# Altium schematic sheet Sheet1.SchDoc
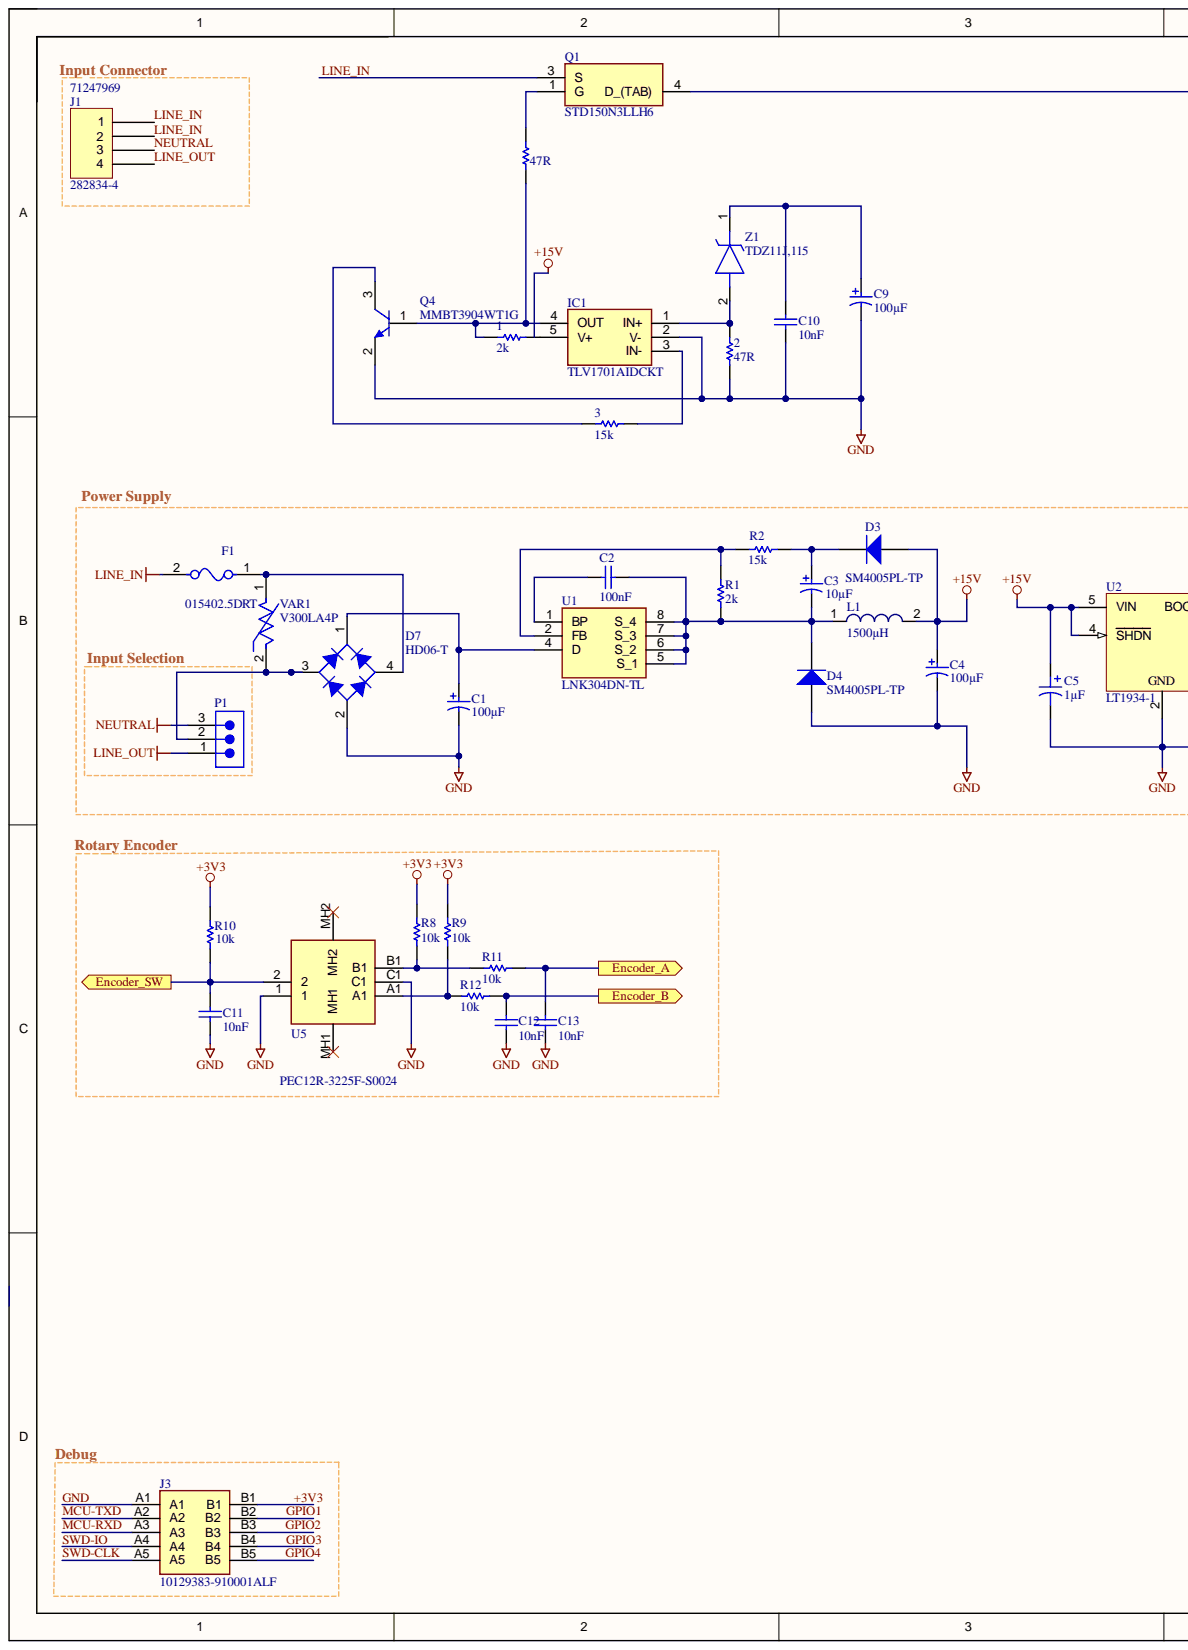
[diagram p0: Sheet1.SchDoc, left]
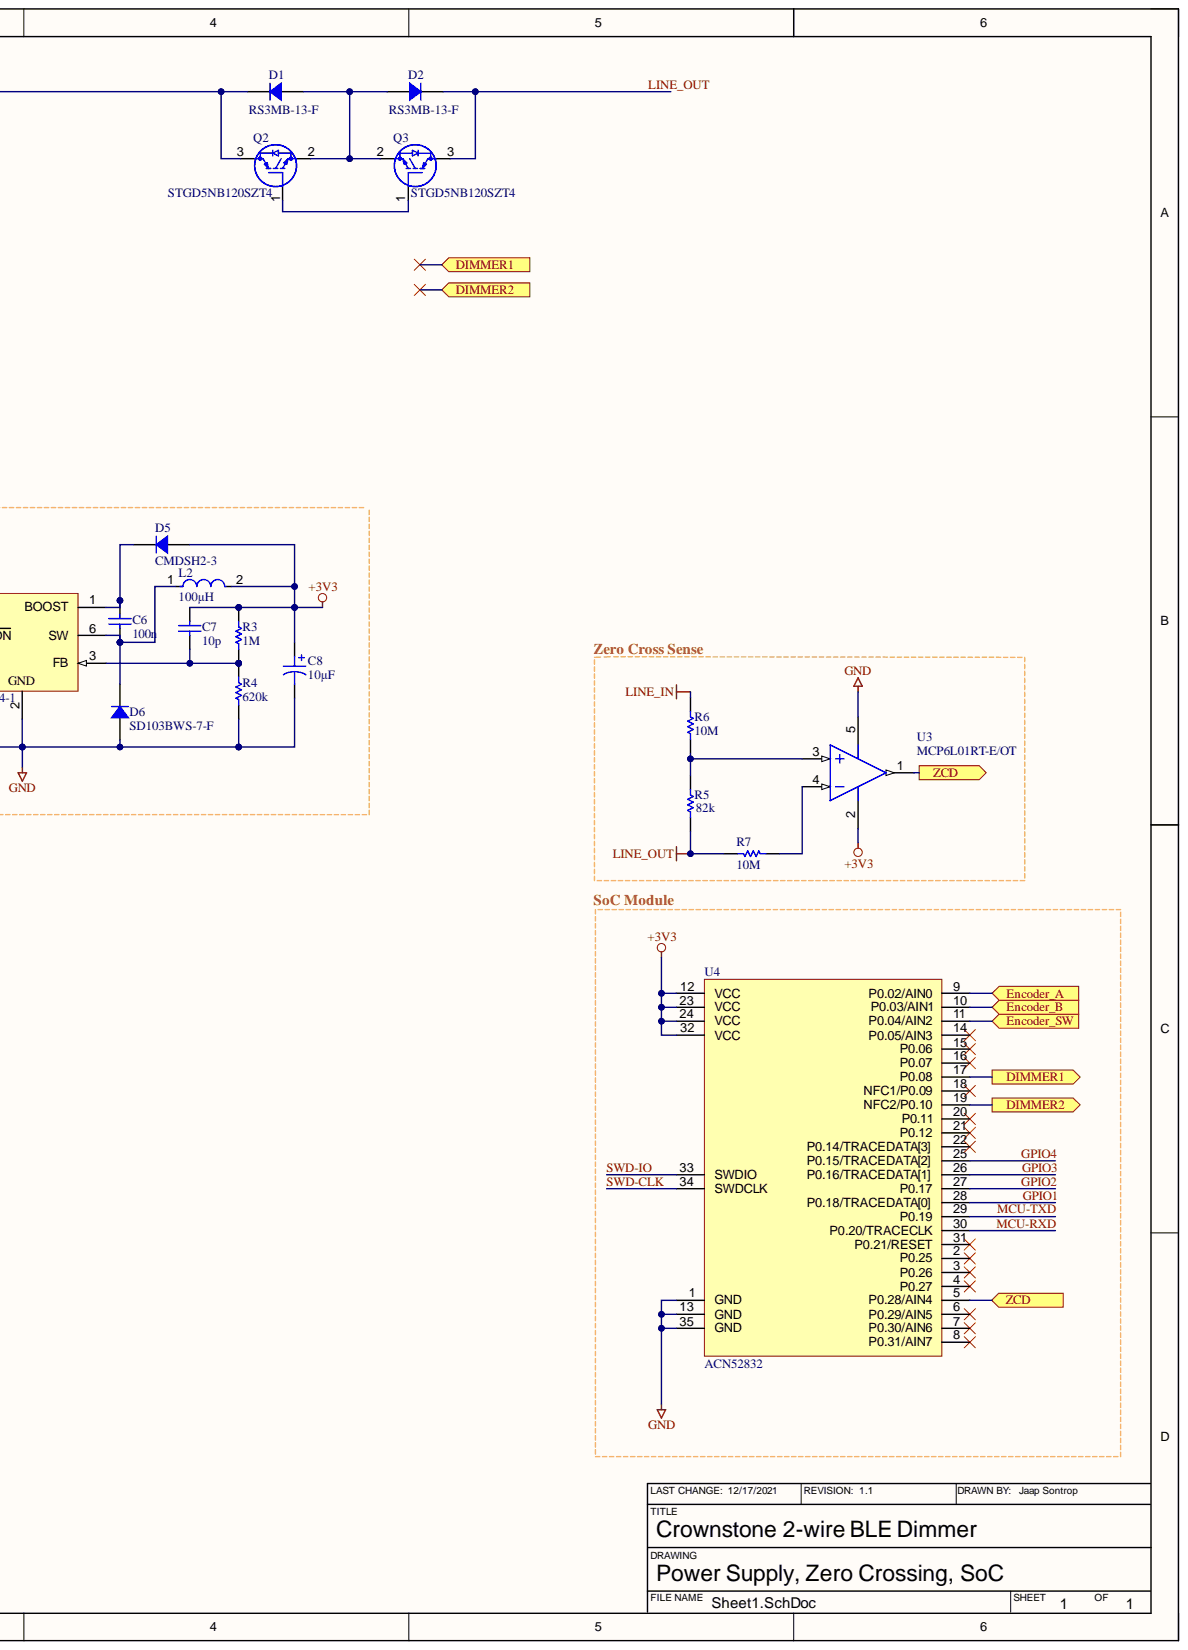
[diagram p1: Sheet1.SchDoc, right]

## Parts
1  2k  [RESC2013X50X30LL20T20]
2  47R  [RESC2013X50X30LL20T20]
3  15k  [RESC2013X50X30LL20T20]
C1  100µF  [NIC-CAPA2-6.3X5.8_V]
C2  100nF  [CAPC2013X145X48NL20T23]
C3  10µF  [NIC-CAPA2-6.3X5.8_V]
C4  100µF  [NIC-CAPA2-6.3X5.8_V]
C5  1µF  [CAP ALSMD POLY 4.3MM H5.5MM]
C6  100n  [CAPC2013X145X48NL20T23]
C7  10p  [CAPC2013X145X48NL20T23]
C8  10µF  [CAP ALSMD 8.3MM H10.5MM]
C9  100µF  [NIC-CAPA2-6.3X5.8_V]
C10  10nF  [CAPC1608X90X35NL15T15]
C11  10nF  [CAPC1608X90X35NL15T15]
C12  10nF  [CAPC1608X90X35NL15T15]
C13  10nF  [CAPC1608X90X35NL15T15]
D1  RS3MB-13-F  [DO214AA SMB]
D2  RS3MB-13-F  [DO214AA SMB]
D3  Manufacturer Part Number: SM4005PL-TP  [MCC-SOD-123FL_V]  (hidden comment: Dfeedback)
D4  Manufacturer Part Number: SM4005PL-TP  [MCC-SOD-123FL_V]  (hidden comment: Dfreewheel)
D5  CMDSH2-3  [SOD323]
D6  SD103BWS-7-F  [SOD323]
D7  HD06-T  [DIOD-MiniDIP-4_V]
F1  015402.5DRT  [FP-0154-MFG]
IC1  TLV1701AIDCKT  [SOT65P210X110-5N]
J1  282834-4  Supplier Part Number 2: 71247969  [TE 282834-4]
J3  10129383-910001ALF  [10129383910001ALF]
L1  1500µH  [1060]
L2  100µH  [FP-LQH3NPH_ME-MFG]
P1  TSW-103-07-F-S  [SMTC-TSW-103-07-X-S]  (value not drawn)
Q1  STD150N3LLH6  [TO228P972X240-3N]
Q2  STGD5NB120SZT4  [LD1117DT33]
Q3  STGD5NB120SZT4  [LD1117DT33]
Q4  MMBT3904WT1G  [ONSC-SC-70-3-419-04_V]
R1  2k  [RESC2013X50X30LL20T20]
R2  15k  [RESC2013X50X30LL20T20]
R3  1M  [RESC2013X50X30LL20T20]
R4  620k  [RESC2013X50X30LL20T20]
R5  82k  [RESC2013X50X30LL20T20]
R6  10M  [RESC2013X50X30LL20T20]
R7  10M  [RESC2013X50X30LL20T20]
R8  10k  [RESC2013X50X30LL20T20]
R9  10k  [RESC2013X50X30LL20T20]
R10  10k  [RESC2013X50X30LL20T20]
R11  10k  [RESC2013X50X30LL20T20]
R12  10k  [RESC2013X50X30LL20T20]
U1  LNK304DN-TL  [SO-8C(D-PACKAGE)]
U2  LT1934-1  [LT-S6-6-TSOT-23_N]
U3  MCP6L01RT-E/OT  [SOT-23-OT5_M]
U4  ACN52832  [ACN52832]  (no BOM)
U5  PEC12R-3225F-S0024  [PEC12R3225FS0024]
VAR1  V300LA4P  [FP-MOV-10D201KTR-MFG]
Z1  TDZ11J,115  [SODFL2512X80N]
  47R  [RESC2013X50X30LL20T20]

## Ports
DIMMER1, DIMMER2, Encoder_A, Encoder_B, Encoder_SW, ZCD

## Nets
+15V: C4.1 C5.1 D3.1 L1.2 U2.4(SHDN) U2.5(VIN)
Net_2: Q1.1 .2
+3V3: C7.2 C8.1 D5.1 J3.B1 L2.2 R3.1 R8.2 R9.2 R10.2 U3.2 U4.12(VCC) U4.23(VCC) U4.24(VCC) U4.32(VCC)
DIMMER1: U4.17(P0.08) ; port DIMMER1
DIMMER2: U4.19(NFC2/P0.10) ; port DIMMER2
Encoder_A: C13.2 R11.1 U4.9(P0.02/AIN0) ; port Encoder_A
Encoder_B: C12.2 R12.1 U4.10(P0.03/AIN1) ; port Encoder_B
Encoder_SW: C11.2 R10.1 U4.11(P0.04/AIN2) U5.2 ; port Encoder_SW
GND: 2.2 C1.2 C4.2 C5.2 C8.2 C9.2 C10.1 C11.1 C12.1 C13.1 D4.1 D6.1 D7.2 IC1.2(V-) J3.A1 Q4.2 R4.2 U2.2 U3.5 U4.1(GND) U4.13(GND) U4.35(GND) U5.1 U5.C1
GPIO1: J3.B2 U4.28(P0.18/TRACEDATA[0])
GPIO2: J3.B3 U4.27(P0.17)
GPIO3: J3.B4 U4.26(P0.16/TRACEDATA[1])
GPIO4: J3.B5 U4.25(P0.15/TRACEDATA[2])
LINE_IN: F1.2 J1.1 J1.2 Q1.3 R6.2
LINE_OUT: D2.2 J1.4 P1.1 Q3.3 R5.2 R7.2
MCU-RXD: J3.A3 U4.30(P0.20/TRACECLK)
MCU-TXD: J3.A2 U4.29(P0.19)
NEUTRAL: J1.3 P1.3
SWD-CLK: J3.A5 U4.34(SWDCLK)
SWD-IO: J3.A4 U4.33(SWDIO)
ZCD: U3.1 U4.5(P0.28/AIN4) ; port ZCD
Net1_1: 1.1 IC1.4(OUT) Q4.1 .1
Net1_2: 1.2 IC1.5(V+)
Net2_1: 2.1 IC1.1(IN+) Z1.2
Net3_1: 3.1 IC1.3(IN-)
Net3_2: 3.2 Q4.3
NetC1_1: C1.1 D7.1 U1.4(D)
NetC2_1: C2.1 C3.2 D4.2 L1.1 R1.1 U1.5(S_1) U1.6(S_2) U1.7(S_3) U1.8(S_4)
NetC2_2: C2.2 U1.1(BP)
NetC3_1: C3.1 D3.2 R2.1
NetC6_1: C6.1 D5.2 U2.1(BOOST)
NetC6_2: C6.2 D6.2 L2.1 U2.6(SW)
NetC7_1: C7.1 R3.2 R4.1 U2.3(FB)
NetC9_1: C9.1 C10.2 Z1.1
NetD1_1: D1.1 D2.1 Q2.2 Q3.2
NetD1_2: D1.2 Q1.4 Q2.3
NetD7_3: D7.3 P1.2 VAR1.2
NetD7_4: D7.4 F1.1 VAR1.1
NetQ2_1: Q2.1 Q3.1
NetR1_2: R1.2 R2.2 U1.2(FB)
NetR5_1: R5.1 R6.1 U3.3
NetR7_1: R7.1 U3.4
NetR8_1: R8.1 R11.2 U5.B1
NetR9_1: R9.1 R12.2 U5.A1

Not connected: U4 2(P0.25) 3(P0.26) 4(P0.27) 6(P0.29/AIN5) 7(P0.30/AIN6) 8(P0.31/AIN7) 14(P0.05/AIN3) 15(P0.06) 16(P0.07) 18(NFC1/P0.09) 20(P0.11) 21(P0.12) 22(P0.14/TRACEDATA[3]) 31(P0.21/RESET); U5 MH1 MH2

## Library data of the parts
1-3 R5-R12  = CMP-2001-04896-1: Manufacturer: Vishay Dale; Part Number: CRCW08050000Z0EA; Component Kind: Standard; Library Name: Altium Content Vault
C1 C3 = CMP-0687-00050-1: [Input cap]; Manufacturer: Nichicon; PartNumber: UUD1C101MCL1GS; Endurance Time: 2000 h; Impedance: 0.44 Ohm; Packing: Dia382mm Reel; Rated Capacitance: 100 uF; Rated Ripple Current (at 100kHz): 230 mA; Rated Voltage: 16 V; Tolerance Rated Capacitance: -20 to 20%; Component Kind: Standard; ComponentLink1Description: Manufacturer URL; ComponentLink1URL: http://www.nichicon.co.jp/; ComponentLink2Description: Datasheet; ComponentLink2URL: http://products.nichicon.co.jp/en/pdf/XJA043/e-ud.pdf; ComponentLink3Description: Package Specification; ComponentLink3URL: http://products.nichicon.co.jp/en/pdf/XJA043/e-ud.pdf; DatasheetVersion: 08/2012; Diameter: 6.3 mm; Length: 5.8 mm; Library Name: Altium Content Vault; Mounting Technology: Surface Mount; PackageDescription: 2-Pin Surface Mount Device, Diameter 6.3 mm, Height 5.8 mm, Pitch 5.4 mm; PackageReference: CAPAE-D6.3H5.8; RoHS: TRUE
C2 C7 = CMP-2007-03167-1: bypass cap; Manufacturer: Vitramon; Part Number: VJ0805Y104KNAAT; Component Kind: Standard; Library Name: Altium Content Vault
C4 C9 = CMP-0687-00050-1: [output cap] (16v) Aluminum Electrolytic Capacitor, UD Series, Low Impedance, 100 uF, 16 V, + / - 20%, -55 to 105 degC, Chip Type, 6.3 x 5.8 mm D x L, Pb-Free, Reel; Manufacturer: Nichicon; PartNumber: UUD1C101MCL1GS; Endurance Time: 2000 h; Impedance: 0.44 Ohm; Packing: Dia382mm Reel; Rated Capacitance: 100 uF; Rated Ripple Current (at 100kHz): 230 mA; Tolerance Rated Capacitance: -20 to 20%; Component Kind: Standard; ComponentLink1Description: Manufacturer URL; ComponentLink1URL: http://www.nichicon.co.jp/; ComponentLink2Description: Datasheet; ComponentLink2URL: http://products.nichicon.co.jp/en/pdf/XJA043/e-ud.pdf; ComponentLink3Description: Package Specification; ComponentLink3URL: http://products.nichicon.co.jp/en/pdf/XJA043/e-ud.pdf; DatasheetVersion: 08/2012; Diameter: 6.3 mm; Length: 5.8 mm; Library Name: Altium Content Vault; Mounting Technology: Surface Mount; PackageDescription: 2-Pin Surface Mount Device, Diameter 6.3 mm, Height 5.8 mm, Pitch 5.4 mm; PackageReference: CAPAE-D6.3H5.8; Rated Voltage: 16 V; RoHS: TRUE
C5 = UWX1H010MCL1GB: CAP ALUM 1UF 20% 50V SMD; Manufacturer: Nichicon; Database Table Name: Capacitors - Aluminum - Electrolytic SMT; Lifetime @ Temp.: 2000 Hrs @ 85°C; Polarization: Polar; Ripple Current @ High Frequency: 12.6mA @ 10kHz; Ripple Current @ Low Frequency: 8.4mA @ 120Hz; Surface Mount Land Size: 0.169" L x 0.169" W (4.30mm x 4.30mm); Applications: General Purpose; Capacitance: 1µF; Component Kind: Standard; ComponentLink1Description: Datasheet; ComponentLink1URL: https://products.nichicon.co.jp/en/pdf/XJA043/e-uwx.pdf; ComponentLink2Description: DigiKey Link; ComponentLink2URL: http://digikey.com/product-detail/en/nichicon/UWX1H010MCL1GB/493-2130-1-ND/590105; Device Package: Radial, Can - SMD; Height - Seated (Max): 0.213" (5.40mm); LastUpdated: 9/2/2020 1:23:44 AM; Library Name: Celestial Altium Library - altium_library.DbLib; Lifecycle Status: Active; Minimum Order: 1; Mounting Type: Surface Mount; Operating Temperature: -40°C ~ 85°C; Package / Case: Radial, Can - SMD; Packaging: TapeAndReel; Part Number: UWX1H010MCL1GB; Part Status: Active; PartId: 7127; Price: 0.05; Series: UWX; Size / Dimension: 0.157" Dia (4.00mm); Supplier 1: DigiKey; Supplier Part Number 1: 493-2130-1-ND; Tolerance: ±20%; Value: 1µF; Voltage - Rated: 50V
C6 = CMP-2007-03167-1: Manufacturer: Vitramon; Part Number: VJ0805Y104KNAAT; Component Kind: Standard; Library Name: Altium Content Vault
C8 = UUX2E6R8MNL1GS: CAP ALUM 6.8UF 20% 250V SMD; Manufacturer: Nichicon; Capacitance: 6.8µF; Database Table Name: Capacitors - Aluminum - Electrolytic SMT; Lifetime @ Temp.: 3000 Hrs @ 105°C; Polarization: Polar; Ripple Current @ High Frequency: 73.48mA @ 10kHz; Ripple Current @ Low Frequency: 44mA @ 120Hz; Surface Mount Land Size: 0.327" L x 0.327" W (8.30mm x 8.30mm); Value: 6.8µF; Applications: General Purpose; Component Kind: Standard; ComponentLink1Description: Datasheet; ComponentLink1URL: http://nichicon-us.com/english/products/pdfs/e-uux.pdf; ComponentLink2Description: DigiKey Link; ComponentLink2URL: http://digikey.com/product-detail/en/nichicon/UUX2E6R8MNL1GS/493-9984-1-ND/3974087; Device Package: Radial, Can - SMD; Height - Seated (Max): 0.413" (10.50mm); LastUpdated: 9/2/2020 1:25:13 AM; Library Name: Celestial Altium Library - altium_library.DbLib; Lifecycle Status: Active; Minimum Order: 1; Mounting Type: Surface Mount; Operating Temperature: -40°C ~ 105°C; Package / Case: Radial, Can - SMD; Packaging: TapeAndReel; Part Number: UUX2E6R8MNL1GS; Part Status: Active; PartId: 7765; Price: 0.25; Series: UUX; Size / Dimension: 0.315" Dia (8.00mm); Supplier 1: DigiKey; Supplier Part Number 1: 493-9984-1-ND; Tolerance: ±20%; Voltage - Rated: 250V
C10-C13 = CMP-2006-04076-1: Manufacturer: AVX Interconnect / Elco; Part Number: 06031C103MAT2A; Component Kind: Standard; Library Name: Altium Content Vault
D1 D2 = RS3MB-13-F: DIODE GEN PURP 1KV 3A SMB; Manufacturer: Diodes Incorporated; Capacitance @ Vr, F: 50pF @ 4V, 1MHz; Current - Reverse Leakage @ Vr: 5µA @ 1000V; Database Table Name: Diodes - Rectifiers - Single; Diode Type: Standard; Reverse Recovery Time (trr): 500ns; Speed: Fast Recovery =< 500ns, > 200mA (Io); Voltage - DC Reverse (Vr) (Max): 1000V; Voltage - Forward (Vf) (Max) @ If: 1.3V @ 3A; Component Kind: Standard; ComponentLink1Description: Datasheet; ComponentLink1URL: //media.digikey.com/pdf/Data%20Sheets/Diodes%20PDFs/RS3A_B~RS3M_B.pdf; ComponentLink2Description: DigiKey Link; ComponentLink2URL: http://digikey.com/product-detail/en/diodes-incorporated/RS3MB-13-F/RS3MB-FDICT-ND/804908; Current - Average Rectified (Io): 3A; LastUpdated: 9/2/2020 1:18:52 AM; Library Name: Celestial Altium Library - altium_library.DbLib; Lifecycle Status: Active; Minimum Order: 1; Mounting Type: Surface Mount; Operating Temperature - Junction: -65°C ~ 150°C; Package / Case: DO-214AA, SMB; Packaging: TapeAndReel; Part Number: RS3MB-13-F; Part Status: Active; PartId: 224; Price: 0.22; Series: -; Supplier 1: DigiKey; Supplier Device Package: SMB; Supplier Part Number 1: RS3MB-FDICT-ND
D3 D4 = CMP-2000-07084-1: Manufacturer: Micro Commercial Components; Average Rectified Current: 1A; Diode Configuration: Single; Diode Type: StandardRecovery; Forward Surge Current Ifsm Max: 30A; Forward Voltage: 1.1V; Max Repetitive Reverse Voltage (Vrrm): 600V; Max Reverse Current: 5uA; Repetitive Reverse Voltage Vrrm Max: 1kV; Category: Rectifier Diodes; Component Kind: Standard; ComponentLink1Description: https://datasheet.ciiva.com/912/sm4001pl-sm4007plsod-123fl-912887.pdf?src-supplier=Digi-Key; ComponentLink1URL: https://datasheet.ciiva.com/912/sm4001pl-sm4007plsod-123fl-912887.pdf?src-supplier=Digi-Key; ComponentLink2Description: https://datasheet.ciiva.com/912/sm4001pl-sm4007plsod-123fl-912885.pdf?src-supplier=Digi-Key; ComponentLink2URL: https://datasheet.ciiva.com/912/sm4001pl-sm4007plsod-123fl-912885.pdf?src-supplier=Digi-Key; ComponentLink3Description: https://datasheet.ciiva.com/912/sm4001pl-sm4007plsod-123fl-912886.pdf?src-supplier=Digi-Key; ComponentLink3URL: https://datasheet.ciiva.com/912/sm4001pl-sm4007plsod-123fl-912886.pdf?src-supplier=Digi-Key; Datasheet URL: http://www.mccsemi.com/up_pdf/SM4001PL-SM4007PL(SOD-123FL).PDF; Datasheet Version: Rev. H, 05/2015; Element Configuration: Single; Forward Current If(AV): 1A; Forward Voltage VF Max: 1.1V; Lead Free: Lead Free; Library Name: Altium Content Vault; Manufacturer URL: http://www.mccsemi.com/; Max Reverse Voltage (DC): 600V; Max Surge Current: 30A; Mount: Surface Mount; Mounting Technology: SurfaceMount; Number of Pins: 2; Package Description: 2-Pin Small Outline Diode, Flat Lead, Body 2.825 x 1.65 mm; Package Quantity: 1; Package Reference: SOD-123FL; Packaging: Tape and Reel; Reverse Voltage: 600V; RoHS: Yes; RoHS Compliant: Yes; Stock 1: 49085; Supplier 1: Digi-Key; Supplier Part Number 1: SM4005PL-TP
D5 = CMDSH2-3 TR PBFREE: DIODE SCHOTTKY 30V 200MA SOD323; Manufacturer: Central Semiconductor Corp; Capacitance @ Vr, F: 15pF @ 10V, 1MHz; Current - Reverse Leakage @ Vr: 50µA @ 30V; Database Table Name: Diodes - Rectifiers - Single; Speed: Small Signal =< 200mA (Io), Any Speed; Voltage - Forward (Vf) (Max) @ If: 550mV @ 200mA; Component Kind: Standard; ComponentLink1Description: Datasheet; ComponentLink1URL: https://my.centralsemi.com/get_document.php?cmp=1&mergetype=pd&mergepath=pd&pdf_id=CMDSH2-3.PDF; ComponentLink2Description: DigiKey Link; ComponentLink2URL: http://digikey.com/product-detail/en/central-semiconductor-corp/CMDSH2-3-TR-PBFREE/1514-CMDSH2-3TRPBFREECT-ND/4806979; Current - Average Rectified (Io): 200mA; Diode Type: Schottky; LastUpdated: 9/2/2020 1:27:25 AM; Library Name: Celestial Altium Library - altium_library.DbLib; Lifecycle Status: Active; Minimum Order: 1; Mounting Type: Surface Mount; Operating Temperature - Junction: -65°C ~ 150°C; Package / Case: SC-76, SOD-323; Packaging: TapeAndReel; Part Number: CMDSH2-3 TR PBFREE; Part Status: Active; PartId: 2925; Price: 0.23; Series: -; Supplier 1: DigiKey; Supplier Device Package: SOD-323; Supplier Part Number 1: 1514-CMDSH2-3TRPBFREECT-ND; Voltage - DC Reverse (Vr) (Max): 30V
D6 = SD103BWS-7-F: DIODE SCHOTTKY 30V 350MA SOD323; Manufacturer: Diodes Incorporated; Current - Average Rectified (Io): 350mA (DC); Current - Reverse Leakage @ Vr: 5µA @ 20V; Database Table Name: Diodes - Rectifiers - Single; Reverse Recovery Time (trr): 10ns; Speed: Fast Recovery =< 500ns, > 200mA (Io); Voltage - Forward (Vf) (Max) @ If: 600mV @ 200mA; Component Kind: Standard; ComponentLink1Description: Datasheet; ComponentLink1URL: https://www.diodes.com/assets/Datasheets/ds30101.pdf; ComponentLink2Description: DigiKey Link; ComponentLink2URL: http://digikey.com/product-detail/en/diodes-incorporated/SD103BWS-7-F/SD103BWS-FDICT-ND/815975; Diode Type: Schottky; LastUpdated: 9/2/2020 1:27:26 AM; Library Name: Celestial Altium Library - altium_library.DbLib; Lifecycle Status: Active; Minimum Order: 1; Mounting Type: Surface Mount; Operating Temperature - Junction: -65°C ~ 125°C; Package / Case: SC-76, SOD-323; Packaging: TapeAndReel; Part Number: SD103BWS-7-F; Part Status: Active; PartId: 3032; Price: 0.08; Series: -; Supplier 1: DigiKey; Supplier Device Package: SOD-323; Supplier Part Number 1: SD103BWS-FDICT-ND; Voltage - DC Reverse (Vr) (Max): 30V
D7 = CMP-2000-06395-1: Glass Passivated Bridge Rectifier, 0.8 A, 600 V, -55 to 150 degC, 4-Pin MiniDIP, RoHS, Tape and Reel; Manufacturer: Diodes Inc; Average Rectified Current-Max: 0.8A; Forward Voltage: 1V; Package Version: 05/2016; Peak Current-Max: 30A; Reverse Current-Max: 5µA; Reverse Voltage-Max [Vrrm]: 600V; Component Kind: Standard; Datasheet URL: http://www.diodes.com/_files/datasheets/ds17003.pdf; Datasheet Version: Rev. 13-2, 12/2010; Library Name: Altium Content Vault; Manufacturer URL: http://www.diodes.com/; Mounting Technology: SurfaceMount; Package Description: 4-Pin Small Outline Integrated Circuit, Body 4.7 x 3.8 mm, Pitch 2.5 mm; Package Reference: MiniDIP-4; Package URL: http://www.diodes.com/_files/packages/MiniDIP.pdf
F1 = CMP-2000-05609-2: FUSE BRD MNT 2.5A 125VAC/VDC SMD; Manufacturer: Littelfuse; Fuse Blow Speed: Slow; Max Fuse Ac Voltage: 125V; Automotive: No; Category: Fuse; Component Kind: Standard; ComponentLink2Description: Datasheet; ComponentLink2URL: https://www.littelfuse.com/~/media/electronics/datasheets/fuses/littelfuse_fuse_154_154t_154l_154tl_datasheet.pdf.pdf; Device Class L1: Circuit Protection; Device Class L2: Fuses; Device Class L3: unset; Digikey Description: FUSE BRD MNT 2.5A 125VAC/VDC SMD; Fuse Current: 2.5A; Height: 3.81mm; Lead Free: Yes; Library Name: Altium Content Vault; Manufacturer Part Number: 015402.5DRT; Max Fuse Dc Voltage: 125V; Mouser Description: Fuse Chip Slow Blow Acting 2.5A SMD Solder Pad 9.73 X 5.03 X 3.81mm T/R CSA/PSE/UL; Octopart: https://octopart.com/015402.5drt-littelfuse-565920; Package: 0154; RoHS: TRUE; Temperature: -55°C to +125°C; Temperature Range High: +125°C; Temperature Range Low: -55°C
IC1 = TLV1701AIDCKT: Integrated Circuit; Manufacturer_Name: Texas Instruments; Component Kind: Standard; Datasheet Link: http://www.ti.com/lit/gpn/TLV1701; Height: 1.1mm; Manufacturer_Part_Number: TLV1701AIDCKT
J1 = 282834-4: Connector; PCB Terminal Block; 13.5A; 400V; 4 positions; MKDSN 1 5/ 4 BK; Manufacturer: TE Connectivity AMP Connectors; Manufacturer Part Number: 1729445; Connector Type: Screw; Current: 10A; Database Table Name: Connectors - Terminal Blocks - Wire to Board; Mating Orientation: Horizontal with Board; Number of Levels: 1; Number of Positions: 4; Pitch: 0.100" (2.54mm); Voltage: 150V; Wire Gauge: 16-30 AWG; Wire Gauge (Max): 30AWG; Wire Gauge (Min): 14AWG; Wire Termination: Screw - Rising Cage Clamp; Category: Terminal Blocks; Color: Green; Component Kind: Standard; ComponentLink1Description: Datasheet; ComponentLink1URL: https://www.te.com/commerce/DocumentDelivery/DDEController?Action=srchrtrv&DocNm=282834&DocType=Customer+Drawing&DocLang=English; ComponentLink2Description: DigiKey Link; ComponentLink2URL: http://digikey.com/product-detail/en/te-connectivity-amp-connectors/282834-4/A98335-ND/1153265; ComponentLink3Description: https://media.digikey.com/pdf/Data%20Sheets/Phoenix%20Contact%20PDFs/1729445.pdf?src-supplier=Digi-Key; ComponentLink3URL: https://media.digikey.com/pdf/Data%20Sheets/Phoenix%20Contact%20PDFs/1729445.pdf?src-supplier=Digi-Key; ComponentLink4Description: http://www.phoenixcontact.com/us/products/1729445/pdf?src-supplier=Mouser; ComponentLink4URL: http://www.phoenixcontact.com/us/products/1729445/pdf?src-supplier=Mouser; Current Rating: 13.5A; Housing Material: Nylon; LastUpdated: 1/15/2020 4:16:05 AM; Library Name: Celestial Altium Library - altium_library.DbLib; Lifecycle Status: Active; Minimum Order: 1; Mount: Through Hole; Mounting Type: Through Hole; Number of Positions Per Level: 4; Package Quantity: 50; Packaging: Bulk; Part Number: 282834-4; Part Status: Active; Positions Per Level: 4; Price: 2.68; RoHS: Yes; RoHS Compliant: Yes; Series: Buchanan; Stock 2: 17; Supplier 1: DigiKey; Supplier 2: Allied Electronics; Supplier Part Number 1: A98335-ND; Termination: Screw; Voltage Rating: 400V; Weight: 10lb
J3 = 10129383-910001ALF: Connector; Manufacturer_Name: Amphenol; Component Kind: Standard; Datasheet Link: https://cdn.amphenol-icc.com/media/wysiwyg/files/drawing/10129383.pdf; Height: 9.85mm; Manufacturer_Part_Number: 10129383-910001ALF
L1 = CMP-1387-00005-1: SMD Power Inductor WE-PD HV, L=1500 µH    7687714152; Manufacturer: Wuerth Elektronik; Inductance: 1500 ÂµH; Component Kind: Standard; ComponentLink1Description: Manufacturer URL; ComponentLink1URL: http://www.we-online.com; ComponentLink2Description: Datasheet; ComponentLink2URL: http://www.we-online.de/katalog/media/pdf/7687714152.pdf; Library Name: Altium Content Vault; PackageReference: 1060; PartNumber: 7687714152
L2 = CMP-06042-014726-1: Wire Wound Ferrite Inductor for Power Lines For Automotive 100μH ±20% 1.908Ω 430mA 1212; Component Kind: Standard; Library Name: Altium Content Vault
P1 = CMP-1024-00331-1: 0.025" SQ Post Header, Through-hole, Vertical, -55 to 125 degC, 2.54 mm Pitch, 3-Pin, Male, RoHS; Manufacturer: Samtec; Pitch: 2.54 mm; Component Kind: Standard; ComponentLink1Description: Manufacturer URL; ComponentLink1URL: http://www.samtec.com; ComponentLink2Description: Datasheet; ComponentLink2URL: http://www.samtec.com/documents/webfiles/pdf/TSW_TH.PDF; ComponentLink3Description: Package Specification; ComponentLink3URL: http://www.samtec.com/documents/webfiles/cpdf/TSW-XXX-XX-XXX-X-XX-XXX-MKT.pdf; DatasheetVersion: F-214; Library Name: Altium Content Vault; Mounting Technology: Through-hole; Orientation: Vertical; PackageDescription: .025[0.64] SQ. Post Terminal Strip Assembly; PackageReference: TSW-103-07-X-S; PackageVersion: DM, 8/1988; PartNumber: TSW-103-07-F-S; RoHS: True; Temperature Range: -55 to 125 degC
Q1 = STD150N3LLH6: Transistor; Manufacturer_Name: STMicroelectronics; Component Kind: Standard; Datasheet Link: http://www.st.com/web/en/resource/technical/document/datasheet/CD00218753.pdf; Height: 2.4mm; Manufacturer_Part_Number: STD150N3LLH6
Q2 Q3 = STGD5NB120SZT4: Transistor IGBT; Manufacturer_Name: STMicroelectronics; Component Kind: Standard; Datasheet Link: https://www.arrow.com/en/products/stgd5nb120szt4/stmicroelectronics; Height: 2mm; Manufacturer_Part_Number: STGD5NB120SZT4
Q4 = CMP-1048-00343-1: General Purpose Transistor, NPN Silicon, 3-Pin SC70, Pb-Free, Tape and Reel; Manufacturer: On Semiconductor; fT Min (MHz): 300; IC Continuous (A): 0.2; Component Kind: Standard; ComponentLink1Description: Manufacturer URL; ComponentLink1URL: http://www.onsemi.com/; ComponentLink2Description: Datasheet; ComponentLink2URL: http://www.onsemi.cn/pub_link/Collateral/MMBT3904WT1-D.PDF; ComponentLink3Description: Package Specification; ComponentLink3URL: http://www.onsemi.cn/pub_link/Collateral/419-04.PDF; DatasheetVersion: Rev. 8; Library Name: Altium Content Vault; Mounting Technology: Surface Mount; PackageDescription: 3-Pin Small Outline Transistor, Body 2 x 1.25 mm, Pitch 0.65 mm; PackageReference: SC-70-3-419-04; PackageVersion: Rev. N, 11/2008; Packing: Tape and Reel; PartNumber: MMBT3904WT1G; Polarity: NPN; RoHS: TRUE
R1 = CMP-2001-04896-1: [feedback resistor (bias)] RBIAS; Manufacturer: Vishay Dale; Part Number: CRCW08050000Z0EA; Component Kind: Standard; Library Name: Altium Content Vault
R2-R4 = CMP-2001-04896-1: [feedback resistor] RFB; Manufacturer: Vishay Dale; Part Number: CRCW08050000Z0EA; Component Kind: Standard; Library Name: Altium Content Vault
U1 = LNK304DN-TL: Integrated Circuit; Manufacturer_Name: Power Integrations; Component Kind: Standard; Datasheet Link: https://componentsearchengine.com//LNK304DN-TL.pdf; Manufacturer_Part_Number: LNK304DN-TL; Mouser2 Part Number: 869-LNK304DN-TL; Mouser2 Price/Stock: https://www.mouser.com/Search/Refine.aspx?Keyword=869-LNK304DN-TL
U2 = CMP-0491-01658-1: Micropower Step-Down Switching Regulator, 6-pin SOT23 (S6-6), -40 to 85 degC, Pb-Free, Mini Tape and Reel; Manufacturer: Linear Technology; PartNumber: LT1934ES6-1#TRMPBF; Number of Outputs: 1; Code_JEDEC: MO-193; Component Kind: Standard; ComponentLink1Description: Manufacturer URL; ComponentLink1URL: http://www.linear.com/; ComponentLink2Description: Datasheet; ComponentLink2URL: http://cds.linear.com/docs/en/datasheet/1934fe.pdf; ComponentLink3Description: Package Specification; ComponentLink3URL: http://cds.linear.com/docs/en/packaging/SOT_6_05-08-1636.pdf; DatasheetVersion: Rev. E; Library Name: Altium Content Vault; Mounting Technology: Surface Mount; PackageDescription: 6-Pin Plastic TSOT-23, Body 2.9 x 1.63 mm, Pitch 0.95 mm; PackageReference: S6-6; PackageVersion: Jun-10; Packing: Mini Tape and Reel; RoHS: TRUE
U3 = CMP-0187-00044-3: None; Amplifier Type: GENERAL PURPOSE; Bandwidth: 1MHz; Common Mode Rejection Ratio: 78dB; Input Bias Current: 2pA; Input Offset Voltage (Vos): 5mV; Max Supply Voltage: 6V; Min Supply Voltage: 1.8V; Nominal Supply Current: 85uA; Number of Amplifiers: 1; Output Current: 20mA; Power Supply Rejection Ratio (PSRR): 83dB; Slew Rate: 0.6V/µs; Voltage Gain: 105dB; Case/Package: SOT-23; Component Kind: Standard; Contact Plating: Tin; Gain Bandwidth Product: 1MHz; Height: 1.3mm; Input Offset Voltage-Max: 5mV; Lead Free: Lead Free; Length: 3.1mm; Library Name: Altium Content Vault; Max Operating Temperature: 125°C; Min Operating Temperature: -40°C; Mount: Surface Mount; Number of Channels: 1; Number of Circuits: 1; Number of Elements: 1; Number of Pins: 5; Package Quantity: 3000; Packaging: Tape and Reel; Radiation Hardening: No; REACH SVHC: No SVHC; RoHS Compliant: Yes; Simulation: MCP6L01; Slew Rate-Nom: 0.6V/µs; Supply Current: 85uA; Technology: CMOS; Unity Gain Bandwidth Product: 1MHz; Width: 1.8mm
U4 = ACN52832: Bluetooth-Module (802.15.1) Bluetooth Low Energy Smart Module; Library Name: ACN52832.IntLib; Supplier: Mouser; Supplier P/N: 364-ACN52832
U5 = PEC12R-3225F-S0024: Undefined or Miscellaneous; Manufacturer_Name: Bourns; Component Kind: Standard; Datasheet Link: https://componentsearchengine.com//PEC12R-3225F-S0024.pdf; Height: 25mm; Manufacturer_Part_Number: PEC12R-3225F-S0024; Mouser2 Part Number: 652-PEC12R3225FS0024; Mouser2 Price/Stock: https://www.mouser.com/Search/Refine.aspx?Keyword=652-PEC12R3225FS0024
VAR1 = CMP-07402-000009-1: Manufacturer: Bourns Inc.; Manufacturer Part Number: MOV-10D201KTR; Capacitance: 600pF; Clamping Current: 25A; Clamping Voltage: 340V; Energy: 25J; Lead/Base Style: Radial; Max Output Current: 1.2kA; Max Varistor Voltage: 517V; Max Voltage Rating (AC): 300V; Max Voltage Rating (DC): 405V; Min Varistor Voltage: 423V; Number of Circuits: 1; Value: 600pF; Varistor Voltage: 185V; Voltage Rating Ac: 130V; Voltage Rating Dc: 170V; Voltage Tolerance: 10%; Automotive: No; Case/Package: Radial; Category: Res; Component Kind: Standard; ComponentLink1Description: https://datasheet.ciiva.com/27053/1764141-27053510.pdf?src-supplier=Jameco; ComponentLink1URL: https://datasheet.ciiva.com/27053/1764141-27053510.pdf?src-supplier=Jameco; ComponentLink2Description: Datasheet; ComponentLink2URL: https://www.bourns.com/docs/Product-Datasheets/MOV10D.pdf; ComponentLink3Description: https://datasheet.ciiva.com/25604/0900766b81107157-25604609.pdf?src-supplier=RSComponents; ComponentLink3URL: https://datasheet.ciiva.com/25604/0900766b81107157-25604609.pdf?src-supplier=RSComponents; ComponentLink4Description: https://datasheet.ciiva.com/8071/482376-8071047.pdf?src-supplier=Element14; ComponentLink4URL: https://datasheet.ciiva.com/8071/482376-8071047.pdf?src-supplier=Element14; ComponentLink5Description: https://datasheet.ciiva.com/4540/littelfuse-mov-la-34212-4540508.pdf?src-supplier=Mouser; ComponentLink5URL: https://datasheet.ciiva.com/4540/littelfuse-mov-la-34212-4540508.pdf?src-supplier=Mouser; ComponentLink6Description: https://datasheet.ciiva.com/365/littelfuse-mov-la-365347.pdf?src-supplier=Digi-Key; ComponentLink6URL: https://datasheet.ciiva.com/365/littelfuse-mov-la-365347.pdf?src-supplier=Digi-Key; ComponentLink7Description: https://datasheet.ciiva.com/16503/la-16503102.pdf?src-supplier=Future+Electronics; ComponentLink7URL: https://datasheet.ciiva.com/16503/la-16503102.pdf?src-supplier=Future+Electronics; Depth: 5.6mm; Device Class L1: Circuit Protection; Device Class L2: Varistors; Device Class L3: unset; Diameter: 7mm; Digikey Description: VARISTOR 200V 2.5KA DISC 10MM; Height: 15.5mm; Lead Free: Yes; Lead Pitch: 6mm; Library Name: Altium Content Vault; Max Operating Temperature: 85°C; Max Surge Current: 1.2kA; Min Operating Temperature: -55°C; Mount: Through Hole,Radial; Mouser Description: Varistors 250pF 200volts 10%; Octopart: https://octopart.com/mov-10d201ktr-bourns-19184757; Operating Supply Voltage: 517V; Package: 0; Package Quantity: 1500; Packaging: Bulk; Pricing 1: 1=0.46000, 10=0.38700, 25=0.33840, 100=0.29010, 250=0.25144, 500=0.21274, 1000=0.16439, 2500=0.14988, 5000=0.14022 (USD); REACH SVHC: Unknown; RoHS: TRUE; RoHS Compliant: Yes; Stock 1: 2692; Supplier 1: Digi-Key; Temperature: -40°C to +105°C; Temperature Range High: +105°C; Temperature Range Low: -40°C; Termination: Radial; Thickness: 5.6mm; Voltage Rating: 405V; Voltage Rating (AC): 300V; Voltage Rating (DC): 405V
Z1 = TDZ11J,115: Zener Diode; Manufacturer_Name: Nexperia; Component Kind: Standard; Datasheet Link: https://assets.nexperia.com/documents/data-sheet/TDZXJ_SER.pdf; Height: 0.8mm; Manufacturer_Part_Number: TDZ11J,115
